# Altium schematic sheet auto_threader.SchDoc(auto_threader)
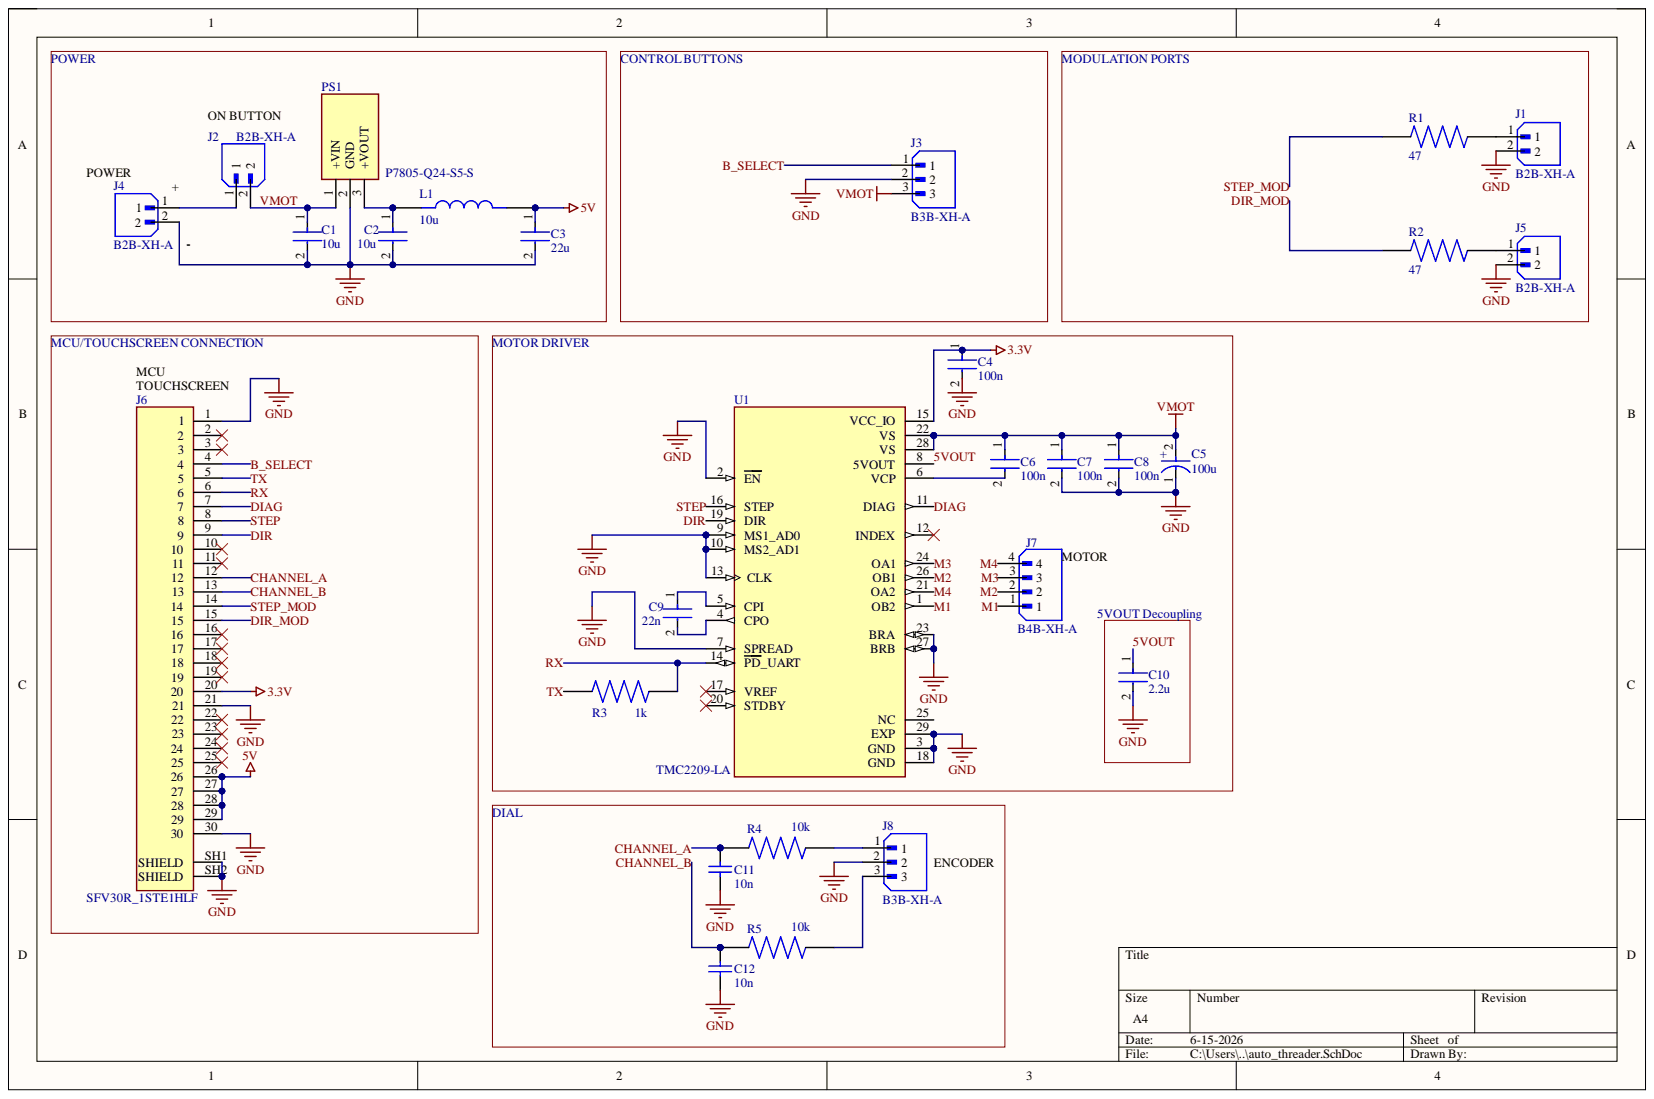
[diagram p0: auto_threader.SchDoc]

## Parts
C1  10u  [GRM21BR61H106KE43L]
C2  10u  [GRM21BR71A106KA73L]
C3  22u  [GRM188R61A226ME15D]
C4  100n  [CC0805KRX7R9BB104]
C5  100u  [35ZLH100MEFC6.3X11]
C6  100n  [CC0805KRX7R9BB104]
C7  100n  [CC0805KRX7R9BB104]
C8  100n  [CC0805KRX7R9BB104]
C9  22n  [CC0603KRX7R9BB223]
C10  2.2u  [GRM155C80J225KE95D]
C11  10n  [GCM188R71H103KA37D]
C12  10n  [GCM188R71H103KA37D]
J1  B2B-XH-A  [B2B-XH-A]
J2  B2B-XH-A  [B2B-XH-A]
J3  B3B-XH-A  [B3B-XH-A]
J4  B2B-XH-A  [B2B-XH-A]
J5  B2B-XH-A  [B2B-XH-A]
J6  SFV30R_1STE1HLF  [SFV30R-1STE1HLF]
J7  B4B-XH-A  [B4B-XH-A]
J8  B3B-XH-A  [B3B-XH-A]
L1  10u  [SRP4020TA-100M]
PS1  P7805-Q24-S5-S  [P7805-Q24-S5-S]
R1  47  [RC0805FR-0747RL]
R2  47  [RC0805FR-0747RL]
R3  1k  [RC0805FR-071KL]
R4  10k  [RC0805FR-0710KL]
R5  10k  [RC0805FR-0710KL]
U1  TMC2209-LA  [TMC2209-LA]

## Nets
3.3V: C4.1 J6.20 U1.15(VCC_IO)
5V: C3.1 J6.26 J6.27 J6.28 J6.29 L1.2
GND: C1.2 C2.2 C3.2 C4.2 C5.1 C7.2 C8.2 C10.2 C11.2 C12.2 J1.2 J3.2 J4.2 J5.2 J6.1 J6.21 J6.30 J6.SH1(SHIELD) J6.SH2(SHIELD) J8.2 PS1.2 U1.2(EN) U1.3(GND) U1.7(SPREAD) U1.9(MS1_AD0) U1.10(MS2_AD1) U1.13(CLK) U1.18(GND) U1.23(BRA) U1.27(BRB) U1.29(EXP)
5VOUT: C10.1 U1.8(5VOUT)
B_SELECT: J3.1 J6.4
CHANNEL_A: C11.1 J6.12 R4.1
CHANNEL_B: C12.1 J6.13 R5.1
DIAG: J6.7 U1.11(DIAG)
DIR: J6.9 U1.19(DIR)
DIR_MOD: J6.15 R2.1
M1: J7.1 U1.1(OB2)
M2: J7.2 U1.26(OB1)
M3: J7.3 U1.24(OA1)
M4: J7.4 U1.21(OA2)
RX: J6.6 R3.2 U1.14(PD_UART)
STEP: J6.8 U1.16(STEP)
STEP_MOD: J6.14 R1.1
TX: J6.5 R3.1
VMOT: C1.1 C5.2 C6.1 C7.1 C8.1 J2.2 J3.3 PS1.1 U1.22(VS) U1.28(VS)
NetC2_1: C2.1 L1.1 PS1.3
NetC6_2: C6.2 U1.6(VCP)
NetC9_1: C9.1 U1.5(CPI)
NetC9_2: C9.2 U1.4(CPO)
NetJ1_1: J1.1 R1.2
NetJ2_1: J2.1 J4.1
NetJ5_1: J5.1 R2.2
NetJ8_1: J8.1 R4.2
NetJ8_3: J8.3 R5.2

Not connected: J6 2 3 10 11 16 17 18 19 22 23 24 25; U1 12(INDEX) 17(VREF) 20(STDBY) 25(NC)
